# Altium schematic sheet ETHERNET.SchDoc(ETHERNET)
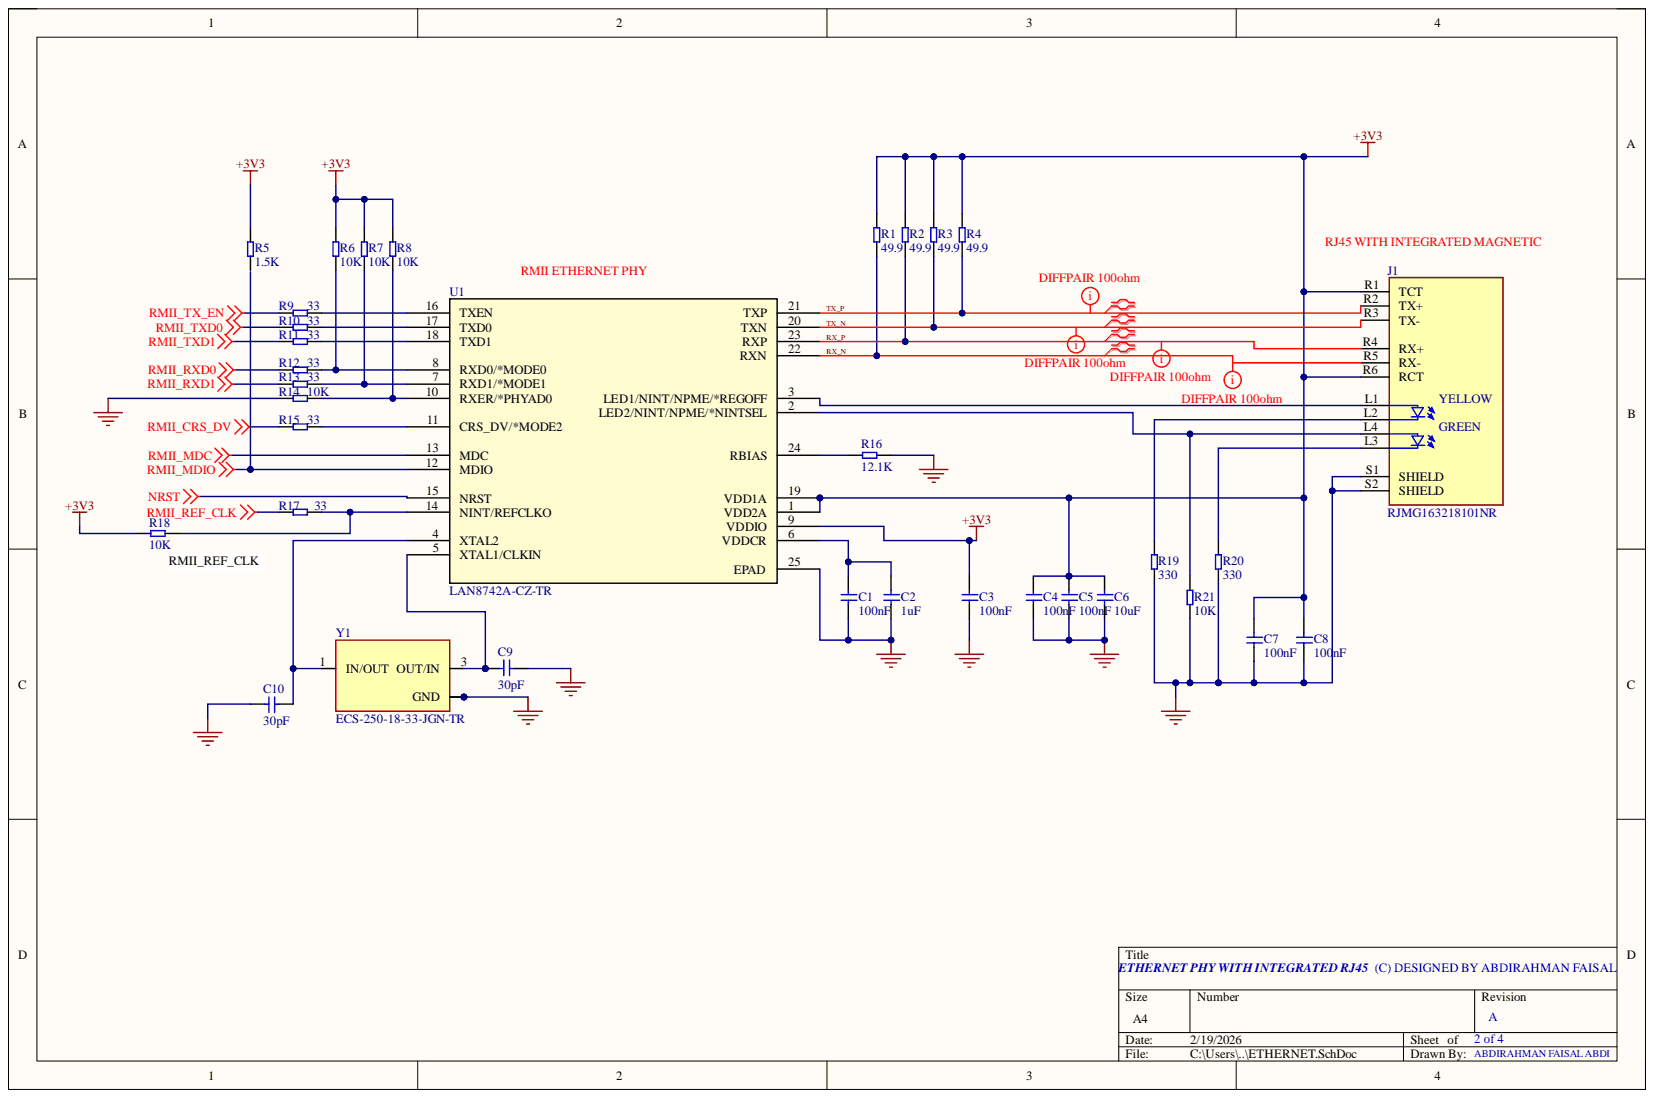
[diagram p0: ETHERNET.SchDoc]

## Parts
C1  100nF  [C0603]
C2  1uF  [C0603]
C3  100nF  [C0603]
C4  100nF  [C0603]
C5  100nF  [C0603]
C6  10uF  [C0603]
C7  100nF  [C0603]
C8  100nF  [C0603]
C9  30pF  [C0603]
C10  30pF  [C0603]
J1  RJMG163218101NR  [AMPHENOL_RJMG163218101NR]
R1  49.9  [R0603]
R2  49.9  [R0603]
R3  49.9  [R0603]
R4  49.9  [R0603]
R5  1.5K  [R0603]
R6  10K  [R0603]
R7  10K  [R0603]
R8  10K  [R0603]
R9  33  [R0603]
R10  33  [R0603]
R11  33  [R0603]
R12  33  [R0603]
R13  33  [R0603]
R14  10K  [R0603]
R15  33  [R0603]
R16  12.1K  [R0603]
R17  33  [R0603]
R18  10K  [R0603]
R19  330  [R0603]
R20  330  [R0603]
R21  10K  [R0603]
U1  LAN8742A-CZ-TR  [SQFN24_4X4MC_MCH]
Y1  ECS-250-18-33-JGN-TR  [XTAL_ECS-250-18-33-JGN-TR]

## Nets
+3V3: C3.2 C4.2 C5.2 C6.2 C7.2 C8.2 J1.R1(TCT) J1.R6(RCT) R1.2 R2.2 R3.2 R4.2 R5.2 R6.2 R7.2 R8.2 R18.2 U1.1(VDD2A) U1.9(VDDIO) U1.19(VDD1A)
GND: C1.1 C2.1 C3.1 C4.1 C5.1 C6.1 C7.1 C8.1 C9.1 C10.1 J1.S1(SHIELD) J1.S2(SHIELD) R14.1 R16.2 R19.1 R20.1 R21.1 U1.25(EPAD) Y1.2(GND) Y1.4
NRST: U1.15(NRST)
RMII_CRS_DV: R15.1
RMII_MDC: U1.13(MDC)
RMII_MDIO: U1.12(MDIO)
RMII_REF_CLK: R17.1
RMII_RXD0: R12.1
RMII_RXD1: R13.1
RMII_TX_EN: R9.1
RMII_TXD0: R10.1
RMII_TXD1: R11.1
RX_N: J1.R5(RX-) R1.1 U1.22(RXN)
RX_P: J1.R4(RX+) R2.1 U1.23(RXP)
TX_N: J1.R3(TX-) R3.1 U1.20(TXN)
TX_P: J1.R2(TX+) R4.1 U1.21(TXP)
NetC1_2: C1.2 C2.2 U1.6(VDDCR)
NetC9_2: C9.2 U1.5(XTAL1/CLKIN) Y1.3(OUT/IN)
NetC10_2: C10.2 U1.4(XTAL2) Y1.1(IN/OUT)
NetJ1_L1: J1.L1 U1.3(LED1/NINT/NPME/*REGOFF)
NetJ1_L2: J1.L2 R19.2
NetJ1_L3: J1.L3 R20.2
NetJ1_L4: J1.L4 R21.2 U1.2(LED2/NINT/NPME/*NINTSEL)
NetR6_1: R6.1 R12.2 U1.8(RXD0/*MODE0)
NetR7_1: R7.1 R13.2 U1.7(RXD1/*MODE1)
NetR8_1: R8.1 R14.2 U1.10(RXER/*PHYAD0)
NetR9_2: R9.2 U1.16(TXEN)
NetR10_2: R10.2 U1.17(TXD0)
NetR11_2: R11.2 U1.18(TXD1)
NetR15_2: R15.2 U1.11(CRS_DV/*MODE2)
NetR16_1: R16.1 U1.24(RBIAS)
NetR17_2: R17.2 R18.1 U1.14(NINT/REFCLKO)

Not connected: R5 1

## Library data of the parts
C1 C3-C5 C7 C8 = CGA3E3X8R1H104K080AD: CAP CER 0.1UF 50V X8R 0603 EPOXY; Manufacturer: TDK; Category: Ceramic Capacitors; Component Kind: Standard; ComponentLink1Description: https://datasheet.ciiva.com/24219/cga3e3x8r1h104k080ad-270768-24219691.pdf?src-supplier=Mouser; ComponentLink1URL: https://datasheet.ciiva.com/24219/cga3e3x8r1h104k080ad-270768-24219691.pdf?src-supplier=Mouser; LCSC part number: C2171928; Library Name: IO.SchLib; Manufacturer Part Number: CGA3E3X8R1H104K080AD; Pricing 1: 1=0.22, 10=0.129, 50=0.0922, 100=0.0693, 500=0.06232, 1000=0.05656 (USD); RoHS: Yes; Stock 1: 30979; Supplier 1: Digikey
C2 = CL10B105KP8NNNC: CAP CER 1UF 10V X7R 0603; Manufacturer: Samsung; Tolerance: 10%; Capacitance: 1uF; Case Code (Imperial): 0603; Case Code (Metric): 1608; Case/Package: 0603; Category: Ceramic Capacitors; Component Kind: Standard; ComponentLink1Description: https://datasheet.ciiva.com/25788/cl10b105kp8nnnc-25788304.pdf?src-supplier=Digikey; ComponentLink1URL: https://datasheet.ciiva.com/25788/cl10b105kp8nnnc-25788304.pdf?src-supplier=Digikey; ComponentLink2Description: https://datasheet.ciiva.com/16396/cl10b105kp8nnnc-16396970.pdf?src-supplier=Future+Electronics; ComponentLink2URL: https://datasheet.ciiva.com/16396/cl10b105kp8nnnc-16396970.pdf?src-supplier=Future+Electronics; ComponentLink3Description: https://datasheet.ciiva.com/26838/getdatasheetpartid-358410-26838426.pdf?src-supplier=Verical; ComponentLink3URL: https://datasheet.ciiva.com/26838/getdatasheetpartid-358410-26838426.pdf?src-supplier=Verical; Construction: Flat; Depth: 0.8mm; Dielectric: X7R; Height: 0.8mm; LCSC part number: C95843; Lead Free: Lead Free; Length: 1.6mm; Library Name: IO.SchLib; Manufacturer Part Number: CL10B105KP8NNNC; Max Operating Temperature: 125°C; Min Operating Temperature: -55°C; Mount: Surface Mount; Package Quantity: 1; Packaging: Tape and Reel; Pricing 1: 1=0.08, 10=0.005 (USD); Radiation Hardening: No; RoHS: Yes; RoHS Compliant: Yes; Series: CL; Stock 1: 5099033; Supplier 1: Digikey; Thickness: 0.035inch; Voltage Rating: 10V; Voltage Rating (DC): 10V; Width: 0.031inch
C6 = GRM188Z71A106KA73D: CAP CER 10UF 10V X7R 0603; Manufacturer: Murata; Material: 4241ConductorMaterial; Tolerance: 10%; Capacitance: 10uF; Case/Package: 345Case; Category: Ceramic Capacitors; Component Kind: Standard; ComponentLink1Description: https://datasheet.octopart.com/GRM188Z71A106KA73D-Murata-datasheet-165579666.pdf?src-supplier=TME; ComponentLink1URL: https://datasheet.octopart.com/GRM188Z71A106KA73D-Murata-datasheet-165579666.pdf?src-supplier=TME; Height: 1mm; LCSC part number: C2959727; Length: 1.6mm; Library Name: IO.SchLib; Manufacturer Part Number: GRM188Z71A106KA73D; Max Operating Temperature: 125°C; Min Operating Temperature: -55°C; Pricing 1: 1=0.28, 10=0.166, 50=0.1204, 100=0.0952, 500=0.08694, 1000=0.07952 (USD); RoHS: Yes; RoHS Compliant: Yes; Stock 1: 55600; Supplier 1: Digikey; Thickness: 800um; Voltage Rating: 10V; Width: 800um
C9 C10 = AC0603JRNPO9BN300: CAP CER 30PF 50V C0G/NPO 0603; Manufacturer: Yageo; Category: Ceramic Capacitors; Component Kind: Standard; LCSC part number: C2191217; Library Name: IO.SchLib; Manufacturer Part Number: AC0603JRNPO9BN300; Pricing 1: 1=0.11, 10=0.061, 50=0.0424, 100=0.035, 500=0.02706, 1000=0.02316 (USD); RoHS: No; Stock 1: 2686; Supplier 1: Digikey
J1 = RJMG163218101NR: Conn RJ-45 RCP 8 POS 2.03mm Solder RA Thru-Hole 10 Terminal 1 Port Tray; Manufacturer: Amphenol; Category: Connectors; Component Kind: Standard; ComponentLink1Description: https://datasheet.ciiva.com/9397/rjmg16xxxxxx1xx-9397614.pdf?src-supplier=Avnet; ComponentLink1URL: https://datasheet.ciiva.com/9397/rjmg16xxxxxx1xx-9397614.pdf?src-supplier=Avnet; LCSC part number: C3179242; Library Name: IO.SchLib; Manufacturer Part Number: RJMG163218101NR; Pricing 1: 1=4.79, 10=4.074, 25=3.8188, 50=3.6364, 100=3.4627, 250=3.24588, 500=3.09098, 1680=2.83786, 3360=2.70258 (USD); RoHS: Yes; Stock 1: 11; Supplier 1: Digikey
R1-R4 = RE0603FRE0749R9L: RES SMD 49.9 OHM 1% 1/10W 0603; Manufacturer: Yageo; Max Power Dissipation: 100mW; Resistance: 49.9R; Temperature Coefficient: 50ppm/°C; Case/Package: 0603; Category: Chip SMD Resistors; Component Kind: Standard; ComponentLink1Description: http://www.yageo.com/NewPortal/yageodocoutput?fileName=/pdf/R-Chip/PYu-RE_105_RoHS_L_4.pdf&src-supplier=Digikey; ComponentLink1URL: http://www.yageo.com/NewPortal/yageodocoutput?fileName=/pdf/R-Chip/PYu-RE_105_RoHS_L_4.pdf&src-supplier=Digikey; ComponentLink2Description: https://datasheet.octopart.com/RE0603FRE0749R9L-Yageo-datasheet-181784607.pdf?src-supplier=Newark; ComponentLink2URL: https://datasheet.octopart.com/RE0603FRE0749R9L-Yageo-datasheet-181784607.pdf?src-supplier=Newark; Features: Moisture Resistant; Height: 0.022inch; LCSC part number: C859439; Length: 0.063inch; Library Name: IO.SchLib; Manufacturer Part Number: RE0603FRE0749R9L; Max Operating Temperature: 155°C; Min Operating Temperature: -55°C; Number of Terminations: 2; Packaging: Tape and Reel; Pricing 1: 1=0.1, 10=0.015, 100=0.0133, 1000=0.00714 (USD); RoHS: Yes; RoHS Compliant: Yes; Series: RE; Stock 1: 13124; Supplier 1: Digikey; Tolerance: 1%; Width: 0.032inch
R5 = RC0603FR-131K5L: RES 1.5K OHM 1% 1/10W 0603; Manufacturer: Yageo; Category: Chip SMD Resistors; Component Kind: Standard; ComponentLink1Description: http://www.mouser.com/ds/2/447/RC0603-272842.pdf?src-supplier=Mouser; ComponentLink1URL: http://www.mouser.com/ds/2/447/RC0603-272842.pdf?src-supplier=Mouser; ComponentLink2Description: https://datasheet.octopart.com/RC0603FR-131K5L-Yageo-datasheet-164759695.pdf?src-supplier=Future+Electronics; ComponentLink2URL: https://datasheet.octopart.com/RC0603FR-131K5L-Yageo-datasheet-164759695.pdf?src-supplier=Future+Electronics; ComponentLink3Description: http://www.yageo.com/pdf/PYu-RC0603_51_RoHS_L_4.pdf?src-supplier=Avnet; ComponentLink3URL: http://www.yageo.com/pdf/PYu-RC0603_51_RoHS_L_4.pdf?src-supplier=Avnet; ComponentLink4Description: https://datasheet.octopart.com/RC0603FR-131K5L-Yageo-Group-datasheet-189192902.pdf?src-supplier=TME; ComponentLink4URL: https://datasheet.octopart.com/RC0603FR-131K5L-Yageo-Group-datasheet-189192902.pdf?src-supplier=TME; LCSC part number: C4093535; Lead Free: 5697LeadFree; Library Name: IO.SchLib; Manufacturer Part Number: RC0603FR-131K5L; Pricing 1: 1=0.1, 10=0.009, 100=0.0071, 1000=0.0051, 2500=0.00408, 5000=0.00306, 10000=0.00204 (USD); RoHS: Yes; Stock 1: 66698; Supplier 1: Digikey
R6-R8 R14 R18 R21 = AC0201FR-0710KL: SMD Chip Resistor, 10 kOhm, ± 1%, 50 mW, 0201 [0603 Metric], Thick Film, General Purpose; Manufacturer: Yageo; Max Power Dissipation: 50mW; Resistance: 10kR; Temperature Coefficient: 200ppm/°C; Case Code (Imperial): 0201; Case Code (Metric): 0603; Case/Package: 0201; Category: Chip SMD Resistors; Component Kind: Standard; ComponentLink1Description: https://datasheet.octopart.com/AC0201FR-0710KL-Yageo-datasheet-166720450.pdf?src-supplier=Future+Electronics; ComponentLink1URL: https://datasheet.octopart.com/AC0201FR-0710KL-Yageo-datasheet-166720450.pdf?src-supplier=Future+Electronics; ComponentLink2Description: http://www.yageo.com/NewPortal/yageodocoutput?fileName=/pdf/R-Chip/PYu-AC_51_RoHS_L_6.pdf&src-supplier=Digikey; ComponentLink2URL: http://www.yageo.com/NewPortal/yageodocoutput?fileName=/pdf/R-Chip/PYu-AC_51_RoHS_L_6.pdf&src-supplier=Digikey; Features: Moisture Resistant,Automotive AEC-Q200; Height: 0.01inch; LCSC part number: C144833; Length: 0.024inch; Library Name: IO.SchLib; Manufacturer Part Number: AC0201FR-0710KL; Max Operating Temperature: 155°C; Min Operating Temperature: -55°C; Number of Terminations: 2; Packaging: Cut Tape; Pricing 1: 1=0.1, 10=0.012, 100=0.0092, 1000=0.00714, 2500=0.00612 (USD); RoHS: Yes; RoHS Compliant: Yes; Series: AC; Stock 1: 68849; Supplier 1: Digikey; Tolerance: 1%; Width: 0.012inch
R9-R13 R15 R17 = RC0603FR-0733RL: RES 33 OHM 1% 1/10W 0603; Manufacturer: Yageo; Max Power Dissipation: 100mW; Resistance: 33R; Temperature Coefficient: 100ppm/°C; Voltage Rating: 75V; Voltage Rating (AC): 50V; Case Code (Imperial): 0603; Case Code (Metric): 1608; Case/Package: 0603; Category: Chip SMD Resistors; Component Kind: Standard; ComponentLink1Description: https://datasheet.ciiva.com/11808/0900766b80f96399-11808741.pdf?src-supplier=Rs; ComponentLink1URL: https://datasheet.ciiva.com/11808/0900766b80f96399-11808741.pdf?src-supplier=Rs; ComponentLink2Description: https://datasheet.ciiva.com/7660/38590-7660792.pdf?src-supplier=Element14; ComponentLink2URL: https://datasheet.ciiva.com/7660/38590-7660792.pdf?src-supplier=Element14; ComponentLink3Description: https://datasheet.ciiva.com/46395/443971-46395007.pdf?src-supplier=Element14; ComponentLink3URL: https://datasheet.ciiva.com/46395/443971-46395007.pdf?src-supplier=Element14; ComponentLink4Description: https://datasheet.ciiva.com/2453/pyu-r-int-thick-7-2453953.pdf?src-supplier=Digikey; ComponentLink4URL: https://datasheet.ciiva.com/2453/pyu-r-int-thick-7-2453953.pdf?src-supplier=Digikey; ComponentLink5Description: https://datasheet.ciiva.com/2453/pyu-r-int-thick-7-2453954.pdf?src-supplier=Digikey; ComponentLink5URL: https://datasheet.ciiva.com/2453/pyu-r-int-thick-7-2453954.pdf?src-supplier=Digikey; ComponentLink6Description: https://datasheet.ciiva.com/2453/pyu-r-int-thick-7-2453952.pdf?src-supplier=Digikey; ComponentLink6URL: https://datasheet.ciiva.com/2453/pyu-r-int-thick-7-2453952.pdf?src-supplier=Digikey; ComponentLink7Description: https://datasheet.ciiva.com/7746/443971-7746334.pdf?src-supplier=Element14; ComponentLink7URL: https://datasheet.ciiva.com/7746/443971-7746334.pdf?src-supplier=Element14; ComponentLink8Description: https://datasheet.ciiva.com/7746/443971-7746335.pdf?src-supplier=Element14; ComponentLink8URL: https://datasheet.ciiva.com/7746/443971-7746335.pdf?src-supplier=Element14; ComponentLink9Description: https://datasheet.ciiva.com/15189/getdatasheetpartid-326673-15189708.pdf?src-supplier=Verical; ComponentLink9URL: https://datasheet.ciiva.com/15189/getdatasheetpartid-326673-15189708.pdf?src-supplier=Verical; Construction: Rectangular; Depth: 0.8mm; Features: Moisture Resistant; Height: 0.45mm; LCSC part number: C108661; Lead Free: 5697LeadFree; Lead Pitch: 0.3mm; Length: 1.6mm; Library Name: IO.SchLib; Manufacturer Part Number: RC0603FR-0733RL; Max Operating Temperature: 155°C; Military Standard: Not; Min Operating Temperature: -55°C; Number of Pins: 2; Number of Terminations: 2; Package Quantity: 1; Packaging: Tape and Reel; Power Rating: 100mW; Pricing 1: 1=0.1, 10=0.011, 100=0.0082, 1000=0.0051, 2500=0.00461 (USD); Reach SVHC Compliant: No; Resistance Tolerance: 1%; Resistor Type: Thick Film; RoHS: Yes; RoHS Compliant: Yes; Series: RC; Size Code: 0603; Stock 1: 100391; Supplier 1: Digikey; Technology: THICK FILM; Termination: SMD/SMT; Tolerance: 1%; Voltage Rating (DC): 50V; Weight: 7.1E-05oz; Width: 0.8mm
R16 = RC0603FR-0712K1L: RES 12.1K OHM 1% 1/10W 0603; Manufacturer: Yageo; Max Power Dissipation: 100mW; Resistance: 12.1kR; Temperature Coefficient: 100ppm/°C; Voltage Rating: 50V; Case Code (Imperial): 0603; Case Code (Metric): 1608; Case/Package: 0603; Category: Chip SMD Resistors; Component Kind: Standard; ComponentLink1Description: https://datasheet.ciiva.com/46395/443971-46395022.pdf?src-supplier=Element14; ComponentLink1URL: https://datasheet.ciiva.com/46395/443971-46395022.pdf?src-supplier=Element14; ComponentLink2Description: https://datasheet.ciiva.com/2519/pyu-r-int-thick-7-2519217.pdf?src-supplier=Digikey; ComponentLink2URL: https://datasheet.ciiva.com/2519/pyu-r-int-thick-7-2519217.pdf?src-supplier=Digikey; ComponentLink3Description: https://datasheet.ciiva.com/2519/pyu-r-int-thick-7-2519218.pdf?src-supplier=Digikey; ComponentLink3URL: https://datasheet.ciiva.com/2519/pyu-r-int-thick-7-2519218.pdf?src-supplier=Digikey; ComponentLink4Description: https://datasheet.ciiva.com/12558/rc0603-272842-12558574.pdf?src-supplier=Mouser; ComponentLink4URL: https://datasheet.ciiva.com/12558/rc0603-272842-12558574.pdf?src-supplier=Mouser; ComponentLink5Description: https://datasheet.ciiva.com/15159/getdatasheetpartid-28802-15159207.pdf?src-supplier=Verical; ComponentLink5URL: https://datasheet.ciiva.com/15159/getdatasheetpartid-28802-15159207.pdf?src-supplier=Verical; Construction: Rectangular; Depth: 0.8mm; Features: Moisture Resistant; Height: 0.45mm; LCSC part number: C123417; Lead Free: 5697LeadFree; Length: 1.6mm; Library Name: IO.SchLib; Manufacturer Part Number: RC0603FR-0712K1L; Max Operating Temperature: 155°C; Military Standard: Not; Min Operating Temperature: -55°C; Number of Pins: 2NUMBER; Number of Terminations: 2; Packaging: Tape and Reel; Power Rating: 100mW; Pricing 1: 1=0.1, 10=0.009, 100=0.0071, 1000=0.00612 (USD); Reach SVHC Compliant: No; Resistance Tolerance: 1%; Resistor Type: Thick Film; RoHS: Yes; RoHS Compliant: Yes; Series: RC; Size Code: 0603; Stock 1: 464570; Supplier 1: Digikey; Termination: SMD/SMT; Tolerance: 1%; Voltage Rating (DC): 50V; Width: 0.8mm
R19 R20 = RC0603FR-07330RL: RES 330 OHM 1% 1/10W 0603; Manufacturer: Yageo; Capacitance: 47uF; ESR (Equivalent Series Resistance): 800mR; Max Power Dissipation: 100mW; Resistance: 330R; Temperature Coefficient: 100ppm/°C; Voltage Rating: 50V; Case Code (Imperial): 0603; Case Code (Metric): 1608; Case/Package: 0603; Category: Chip SMD Resistors; Component Kind: Standard; Depth: 0.8mm; Features: Moisture Resistant; Height: 0.45mm; LCSC part number: C105881; Lead Free: 5697LeadFree; Length: 1.6mm; Library Name: IO.SchLib; Manufacturer Part Number: RC0603FR-07330RL; Max Operating Temperature: 155°C; Min Operating Temperature: -55°C; Number of Pins: 2; Number of Terminations: 2; Package Quantity: 1; Packaging: Tape and Reel; Power Rating: 100mW; Pricing 1: 1=0.1, 10=0.009, 100=0.0082, 1000=0.00612, 2500=0.00461 (USD); Reach SVHC Compliant: No; Resistance Tolerance: 1%; Resistor Type: Thick Film; RoHS: Yes; RoHS Compliant: Yes; Series: RC; Size Code: 0603; Stock 1: 425796; Supplier 1: Digikey; Technology: THICK FILM; Termination: SMD/SMT; Tolerance: 1%; Voltage Rating (AC): 50V; Voltage Rating (DC): 50V; Width: 0.8mm
U1 = LAN8742A-CZ-TR: IC TRANSCEIVER 1/1 24SQFN; Manufacturer: Microchip; Interface: 1515Interface; Number of Channels: 1NUMBER; Category: Ethernet Interface ICs; Component Kind: Standard; ComponentLink1Description: https://datasheet.ciiva.com/29882/8742a-29882620.pdf?src-supplier=Avnet; ComponentLink1URL: https://datasheet.ciiva.com/29882/8742a-29882620.pdf?src-supplier=Avnet; Height: 1mm; LCSC part number: C621424; Library Name: IO.SchLib; Manufacturer Part Number: LAN8742A-CZ-TR; Pricing 1: 1=1.53, 25=1.28, 100=1.17 (USD); RoHS: Yes; Stock 1: 19050; Supplier 1: Digikey
Y1 = ECS-250-18-33-JGN-TR (2): CRYSTAL 25.0000MHZ 18PF SMD; Manufacturer: ECS International; ESR (Equivalent Series Resistance): 40R; Frequency: 25MHz; Frequency Stability: 30ppm; Frequency Tolerance: 20ppm; Case/Package: SMD/SMT; Category: Crystals; Component Kind: Standard; ComponentLink1Description: https://www.ecsxtal.com/store/pdf/ecx-32.pdf?src-supplier=Digikey; ComponentLink1URL: https://www.ecsxtal.com/store/pdf/ecx-32.pdf?src-supplier=Digikey; ComponentLink2Description: https://datasheet.octopart.com/ECS-250-18-33-JGN-TR-ECS-International-datasheet-140089731.pdf?src-supplier=Future+Electronics; ComponentLink2URL: https://datasheet.octopart.com/ECS-250-18-33-JGN-TR-ECS-International-datasheet-140089731.pdf?src-supplier=Future+Electronics; ComponentLink3Description: https://datasheet.octopart.com/ECS-250-18-33-JGN-TR-ECS-International-datasheet-17018741.pdf?src-supplier=Electro+Sonic; ComponentLink3URL: https://datasheet.octopart.com/ECS-250-18-33-JGN-TR-ECS-International-datasheet-17018741.pdf?src-supplier=Electro+Sonic; ComponentLink4Description: https://datasheet.octopart.com/ECS-250-18-33-JGN-TR-ECS-International-datasheet-179230830.pdf?src-supplier=Master+Electronics; ComponentLink4URL: https://datasheet.octopart.com/ECS-250-18-33-JGN-TR-ECS-International-datasheet-179230830.pdf?src-supplier=Master+Electronics; Library Name: IO.SchLib; Load Capacitance: 18pF; Manufacturer Part Number: ECS-250-18-33-JGN-TR; Max Operating Temperature: 85°C; Min Operating Temperature: -40°C; Mount: Surface Mount; Packaging: Cut Tape; Pricing 1: 1=0.41, 10=0.355, 25=0.336, 50=0.3222, 100=0.3089, 250=0.29208, 500=0.28 (USD); RoHS: Yes; RoHS Compliant: Yes; Series: ECX-32; Stock 1: 39748; Supplier 1: Digikey
